# Altium schematic sheet 05_GPIO.SchDoc
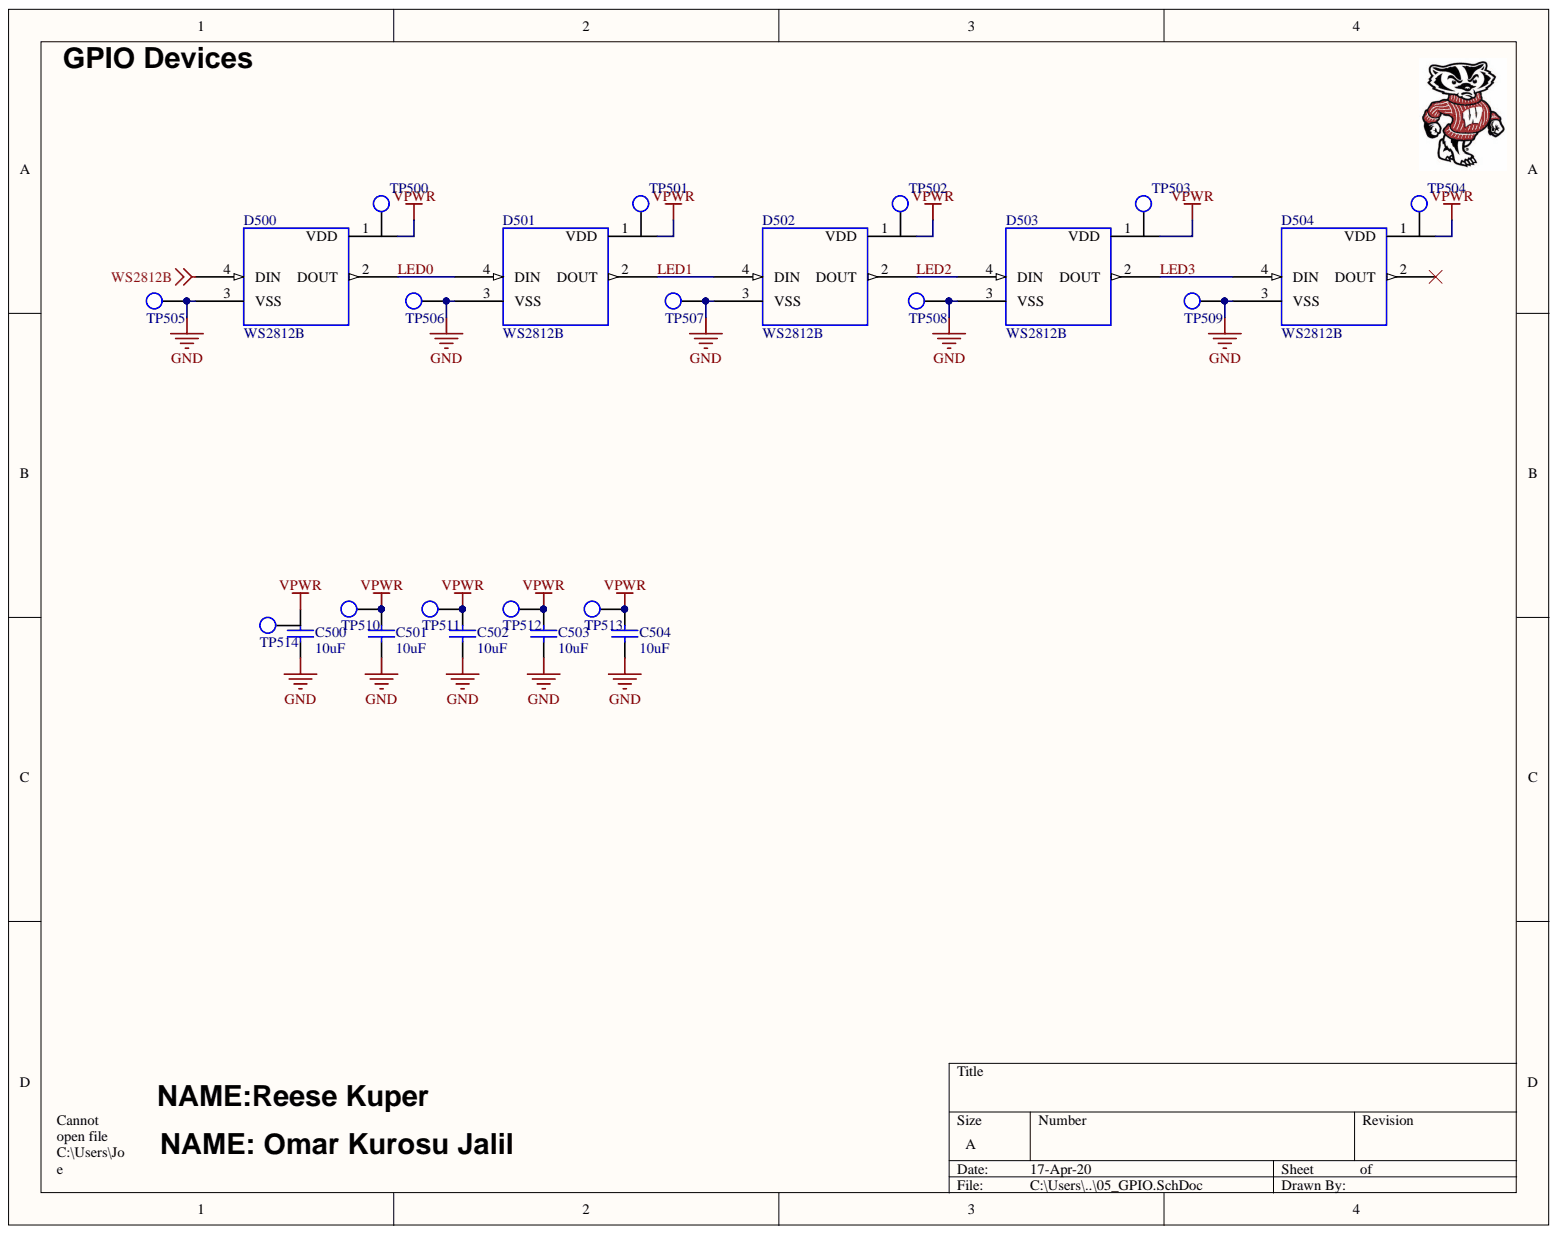
[diagram p0: 05_GPIO.SchDoc]

## Parts
C500  10uF  [CAP_0805]
C501  10uF  [CAP_0805]
C502  10uF  [CAP_0805]
C503  10uF  [CAP_0805]
C504  10uF  [CAP_0805]
D500  WS2812B  [WS2812B]
D501  WS2812B  [WS2812B]
D502  WS2812B  [WS2812B]
D503  WS2812B  [WS2812B]
D504  WS2812B  [WS2812B]
TP500  TP_1206  [TP-SMC-1206]  (value not drawn)
TP501  TP_1206  [TP-SMC-1206]  (value not drawn)
TP502  TP_1206  [TP-SMC-1206]  (value not drawn)
TP503  TP_1206  [TP-SMC-1206]  (value not drawn)
TP504  TP_1206  [TP-SMC-1206]  (value not drawn)
TP505  TP_1206  [TP-SMC-1206]  (value not drawn)
TP506  TP_1206  [TP-SMC-1206]  (value not drawn)
TP507  TP_1206  [TP-SMC-1206]  (value not drawn)
TP508  TP_1206  [TP-SMC-1206]  (value not drawn)
TP509  TP_1206  [TP-SMC-1206]  (value not drawn)
TP510  TP_1206  [TP-SMC-1206]  (value not drawn)
TP511  TP_1206  [TP-SMC-1206]  (value not drawn)
TP512  TP_1206  [TP-SMC-1206]  (value not drawn)
TP513  TP_1206  [TP-SMC-1206]  (value not drawn)
TP514  TP_1206  [TP-SMC-1206]  (value not drawn)

## Nets
GND: C500.1 C501.1 C502.1 C503.1 C504.1 D500.3(VSS) D501.3(VSS) D502.3(VSS) D503.3(VSS) D504.3(VSS) TP505.1 TP506.1 TP507.1 TP508.1 TP509.1
VPWR: C500.2 C501.2 C502.2 C503.2 C504.2 D500.1(VDD) D501.1(VDD) D502.1(VDD) D503.1(VDD) D504.1(VDD) TP510.1 TP511.1 TP512.1 TP513.1
WS2812B: D500.4(DIN)
LED0: D500.2(DOUT) D501.4(DIN)
LED1: D501.2(DOUT) D502.4(DIN)
LED2: D502.2(DOUT) D503.4(DIN)
LED3: D503.2(DOUT) D504.4(DIN)

Not connected: D504 2(DOUT); TP500 1; TP501 1; TP502 1; TP503 1; TP504 1; TP514 1

## Library data of the parts
C500-C504 = CAP_0805_U_010.00: CAP CER 10UF 16V X7R 0805; Manufacturer: Samsung; Manufacturer Part Number: CL21A106KPCLQNC; Dielectric: X5R; Tolerance: 10%; Voltage Rating: 10V; Capacitance: 10uF; Case/Package: 0805; Case Code (Imperial): 0805; Case Code (Metric): 2012; Component Kind: Standard; ComponentLink1Description: https://datasheet.ciiva.com/25730/cl21a106kpclqnc-25730594.pdf; ComponentLink1URL: https://datasheet.ciiva.com/25730/cl21a106kpclqnc-25730594.pdf; ComponentLink3Description: https://datasheet.ciiva.com/26920/getdatasheetpartid-355170-26920201.pdf; ComponentLink3URL: https://datasheet.ciiva.com/26920/getdatasheetpartid-355170-26920201.pdf; Contact Plating: Tin; Depth: 1.25mm; Height: 0.85mm; Length: 2mm; Max Operating Temperature: 85°C; Min Operating Temperature: -55°C; Mount: Surface Mount; Package Quantity: 1; Packaging: Tape and Reel; Pricing 1: 1=0.22, 10=0.148, 100=0.0749, 500=0.05122, 1000=0.04531 (USD); Radiation Hardening: No; Stock 1: 40000; Supplier 1: Digi-Key; Supplier Part Number 1: 1276-2402-1-ND; Thickness: 0.037inch; Width: 0.049inch; Library Name: ece315-2018-fall.SchLib
D500-D504 = WS2812B: LED RGB WS2812B; Manufacturer Part Number: EBAY; Rating: 3.5V-5.3V; Component Kind: Standard; Library Name: LEDs.SchLib; Value: WS2812B
TP500-TP514 = TP_1206: Test Point SMD 1206; Manufacturer: TE Connectivity (Tyco Electronics); Manufacturer Part Number: 1625854-1; Power Rating: N/R; Resistance Value: 0 Ohm; Case Size: 1206; Component Kind: Standard; ComponentLink1Description: https://datasheet.ciiva.com/6439/eng-ds-1773266-a-6439169.pdf; ComponentLink1URL: https://datasheet.ciiva.com/6439/eng-ds-1773266-a-6439169.pdf; ComponentLink2Description: https://datasheet.ciiva.com/30136/1773266-a-30136341.pdf; ComponentLink2URL: https://datasheet.ciiva.com/30136/1773266-a-30136341.pdf; ComponentLink3Description: https://datasheet.ciiva.com/6439/eng-ds-1773266-a-6439080.pdf; ComponentLink3URL: https://datasheet.ciiva.com/6439/eng-ds-1773266-a-6439080.pdf; ComponentLink4Description: https://datasheet.ciiva.com/6439/eng-ds-1773266-a-6439081.pdf; ComponentLink4URL: https://datasheet.ciiva.com/6439/eng-ds-1773266-a-6439081.pdf; ComponentLink5Description: https://datasheet.ciiva.com/6439/eng-ds-1773266-a-6439083.pdf; ComponentLink5URL: https://datasheet.ciiva.com/6439/eng-ds-1773266-a-6439083.pdf; Dimension: 3.2 x 1.6 x 1.25mm; Family: RC; Library Name: ece315-S20.SCHLIB; Mounting: Surface Mount; Operating Temperature: -55 to 125 C; Packaging: Tape and Reel; Part No: 1625854-1; Pricing 1: 1=0.28, 10=0.261, 25=0.224, 50=0.1902, 100=0.1828, 250=0.1641, 500=0.1567, 1000=0.1306 (USD); RoHS: Yes; Stock 1: 31937; Supplier 1: Digi-Key; Supplier Part Number 1: A106143CT-ND; Temperature Coefficient: N/R; Terminal Pitch: N/R; Termination Style: N/R; Tolerance: N/R
